# Altium schematic sheet VoltageRegulator5V.schdoc
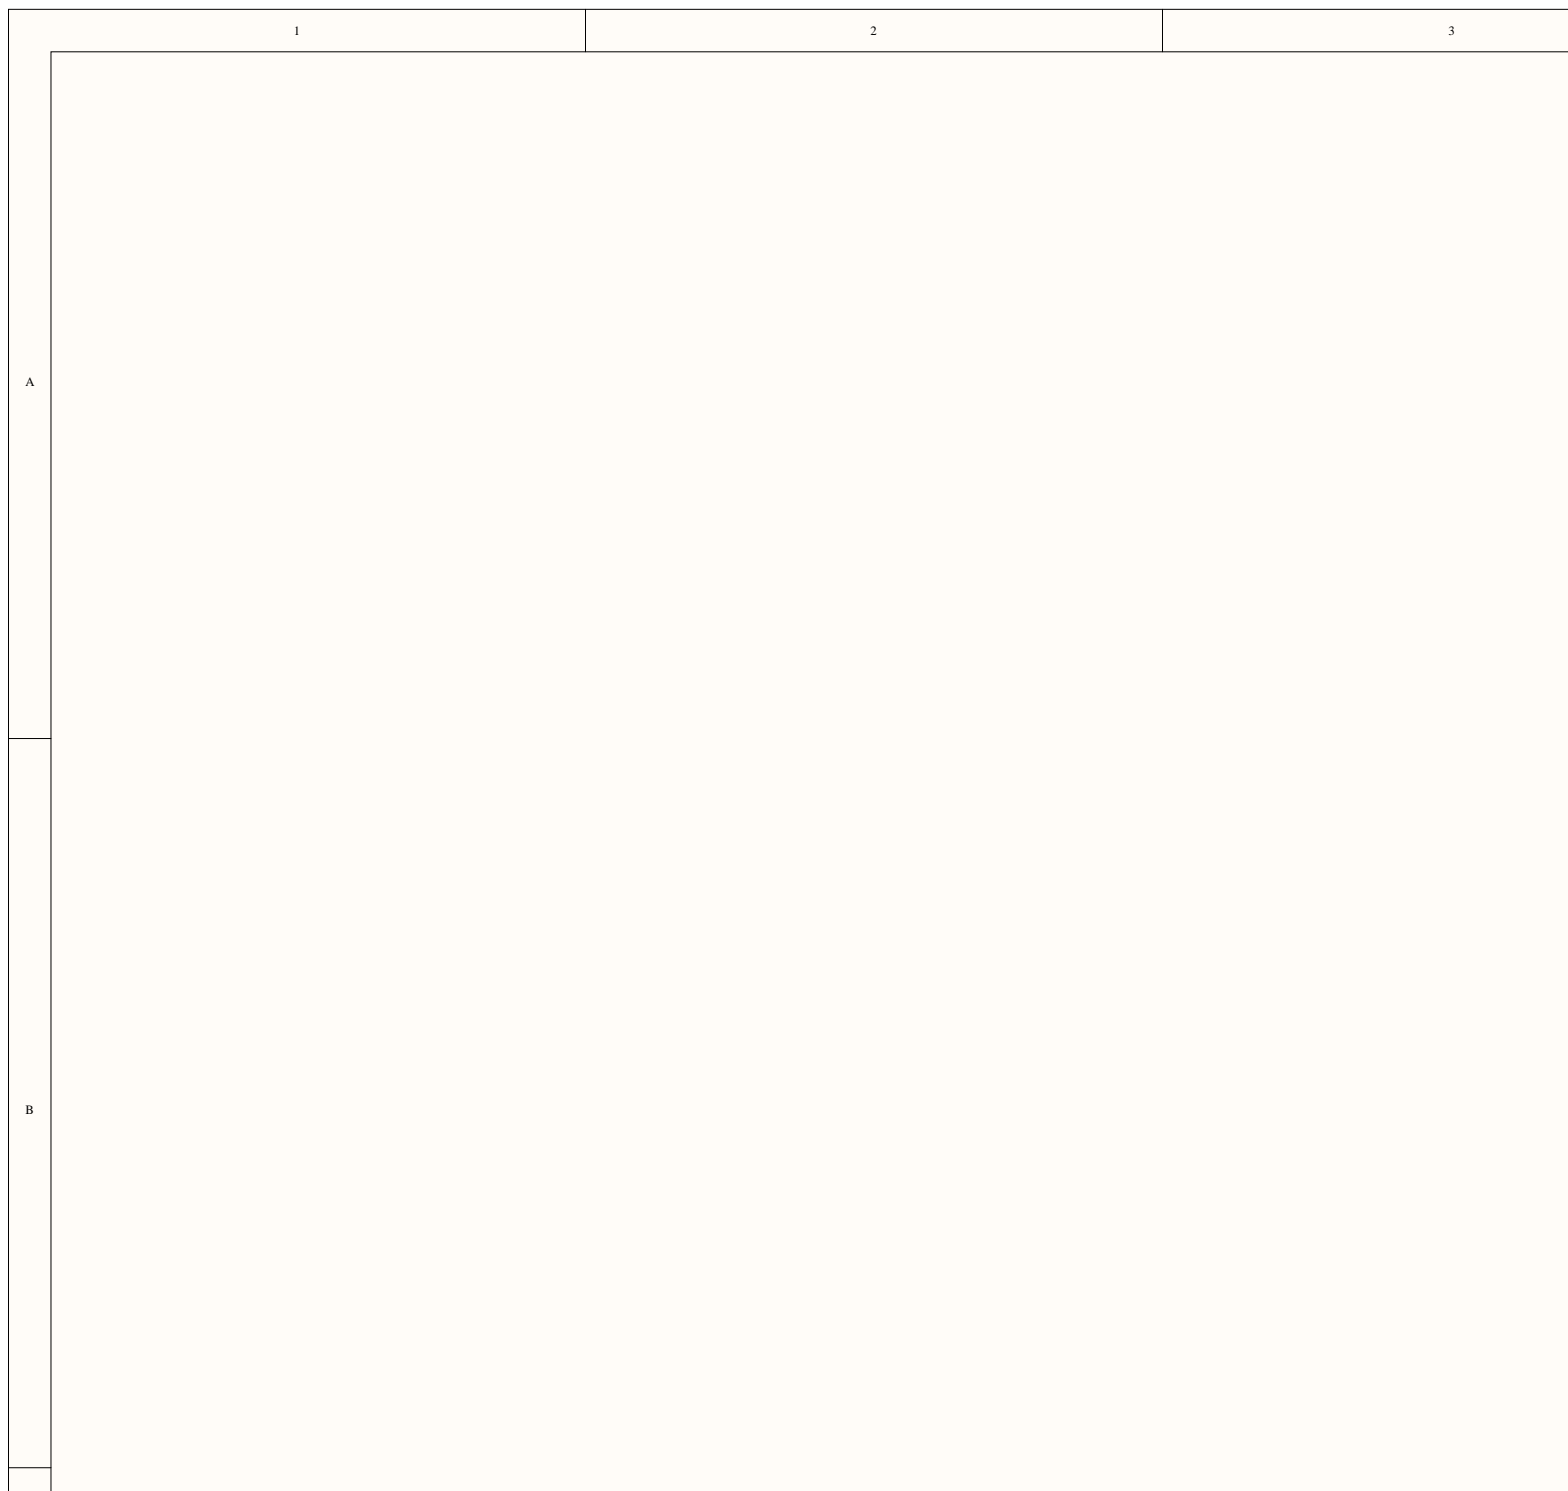
[diagram p0: VoltageRegulator5V.schdoc, top left]
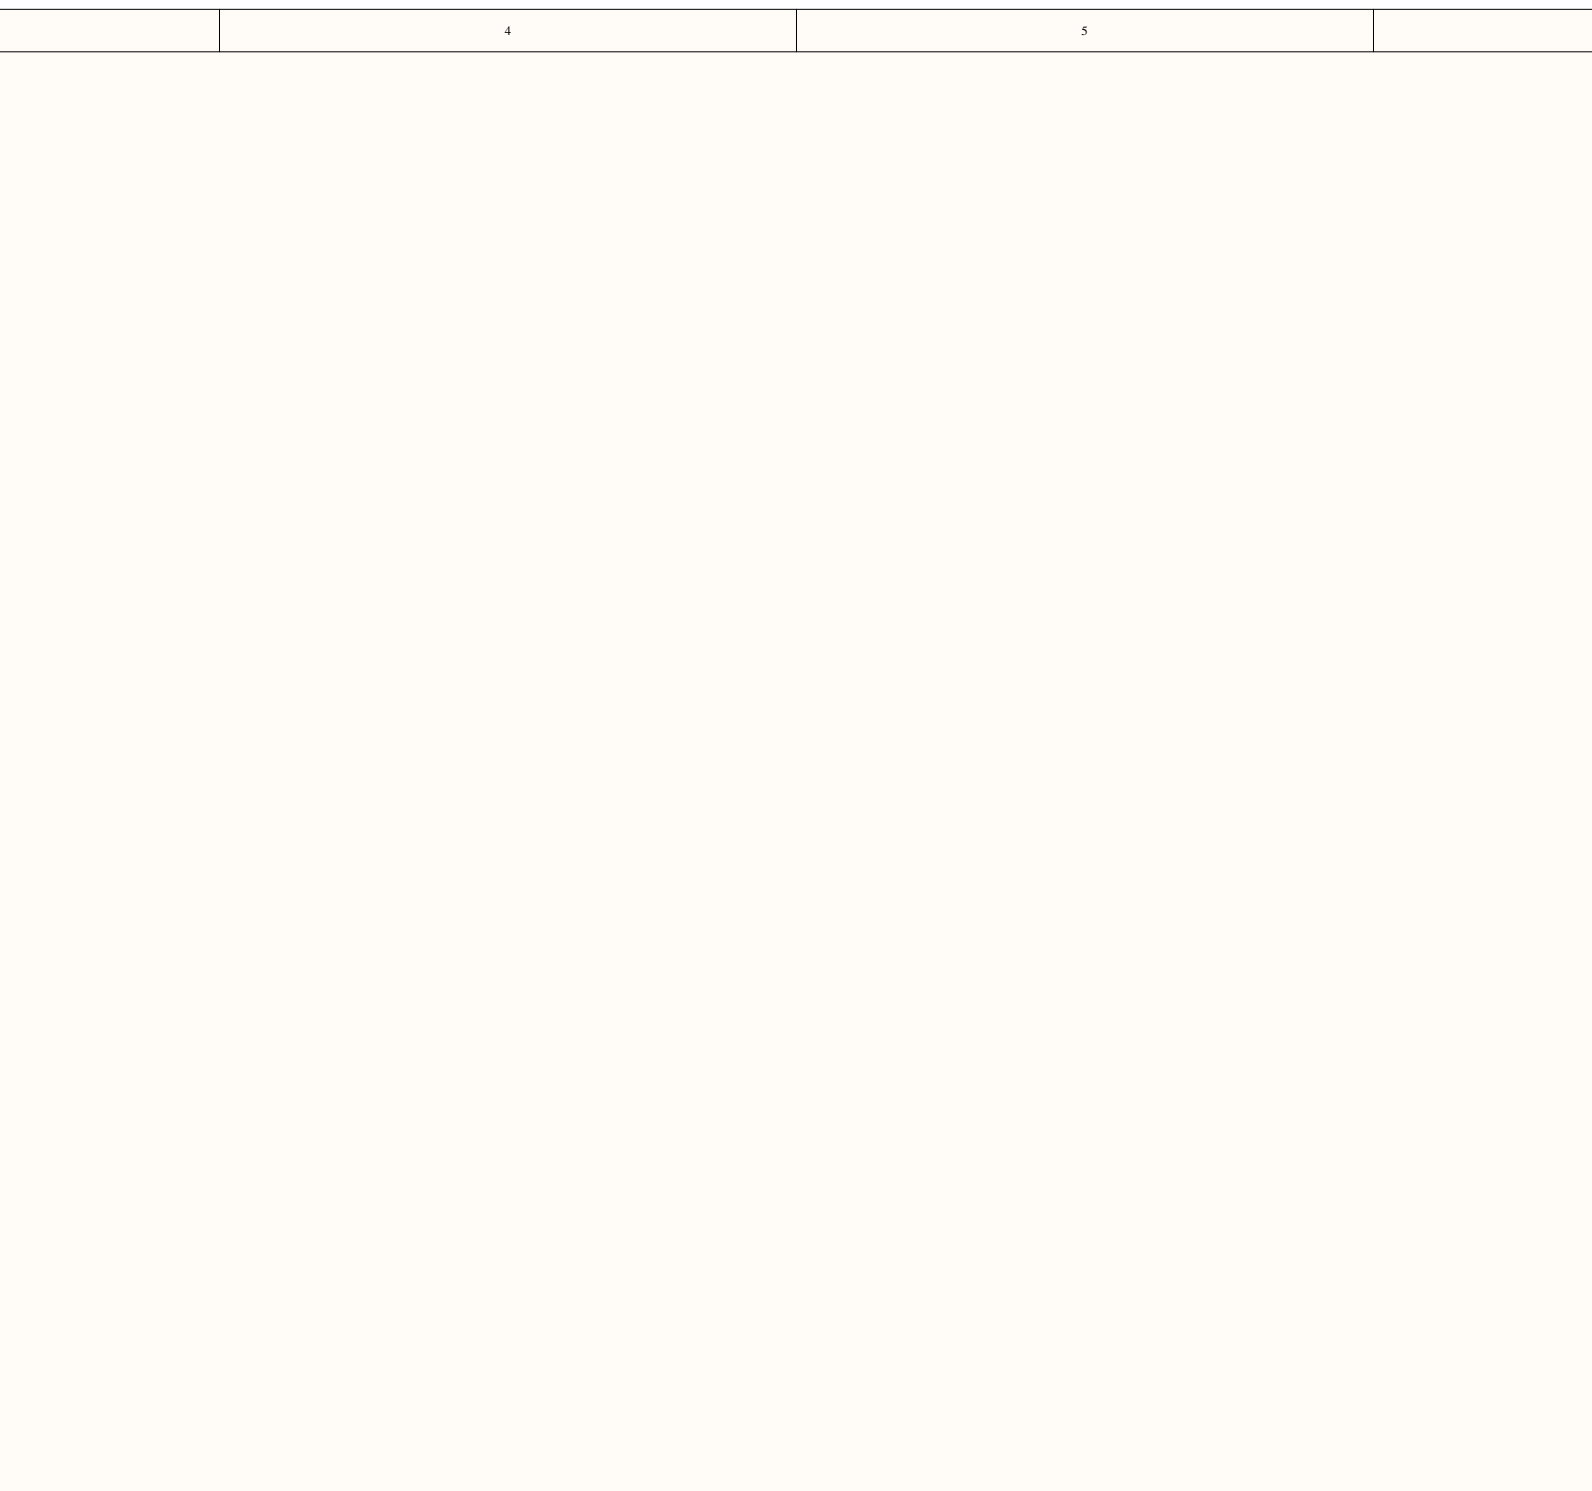
[diagram p1: VoltageRegulator5V.schdoc, top centre]
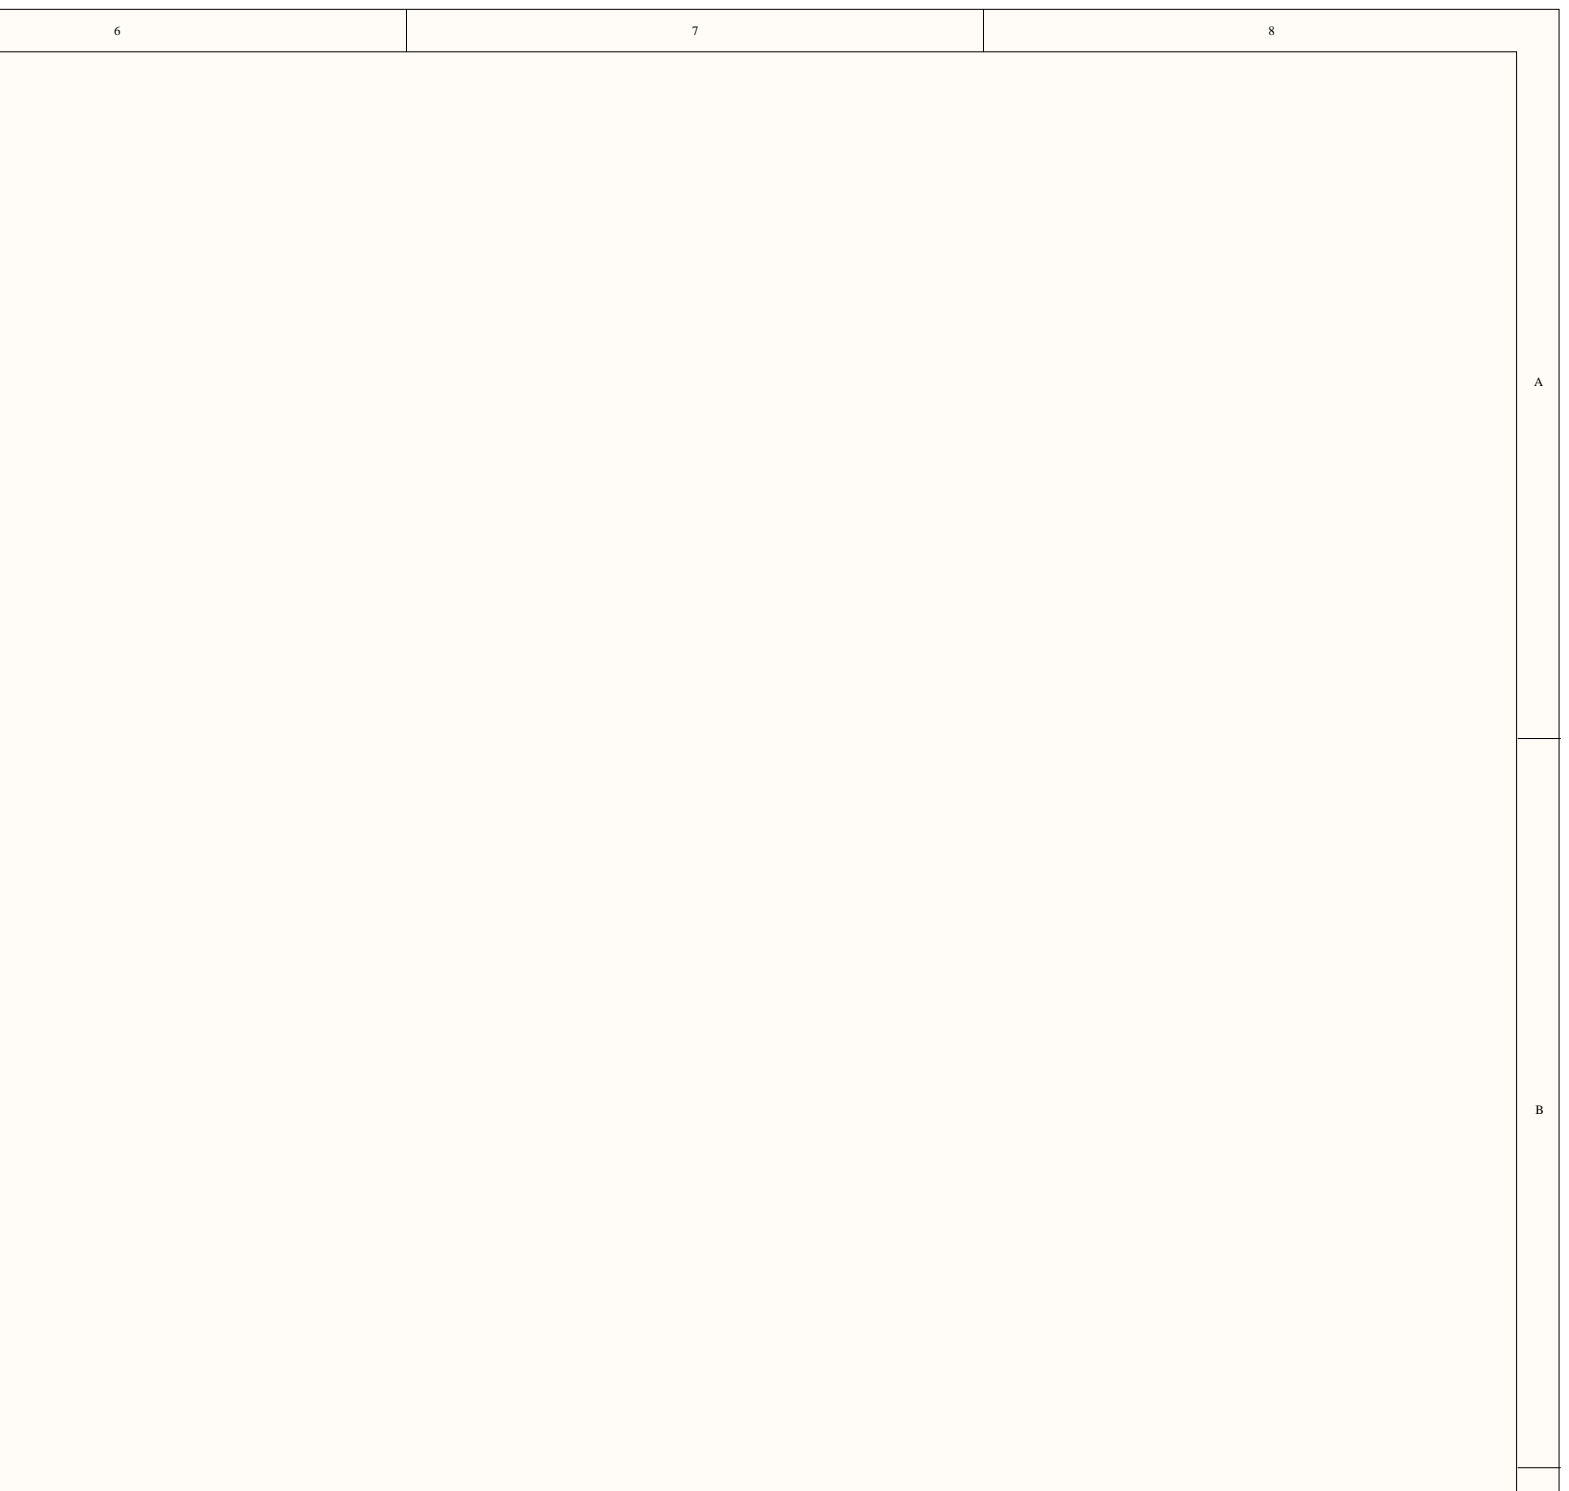
[diagram p2: VoltageRegulator5V.schdoc, top right]
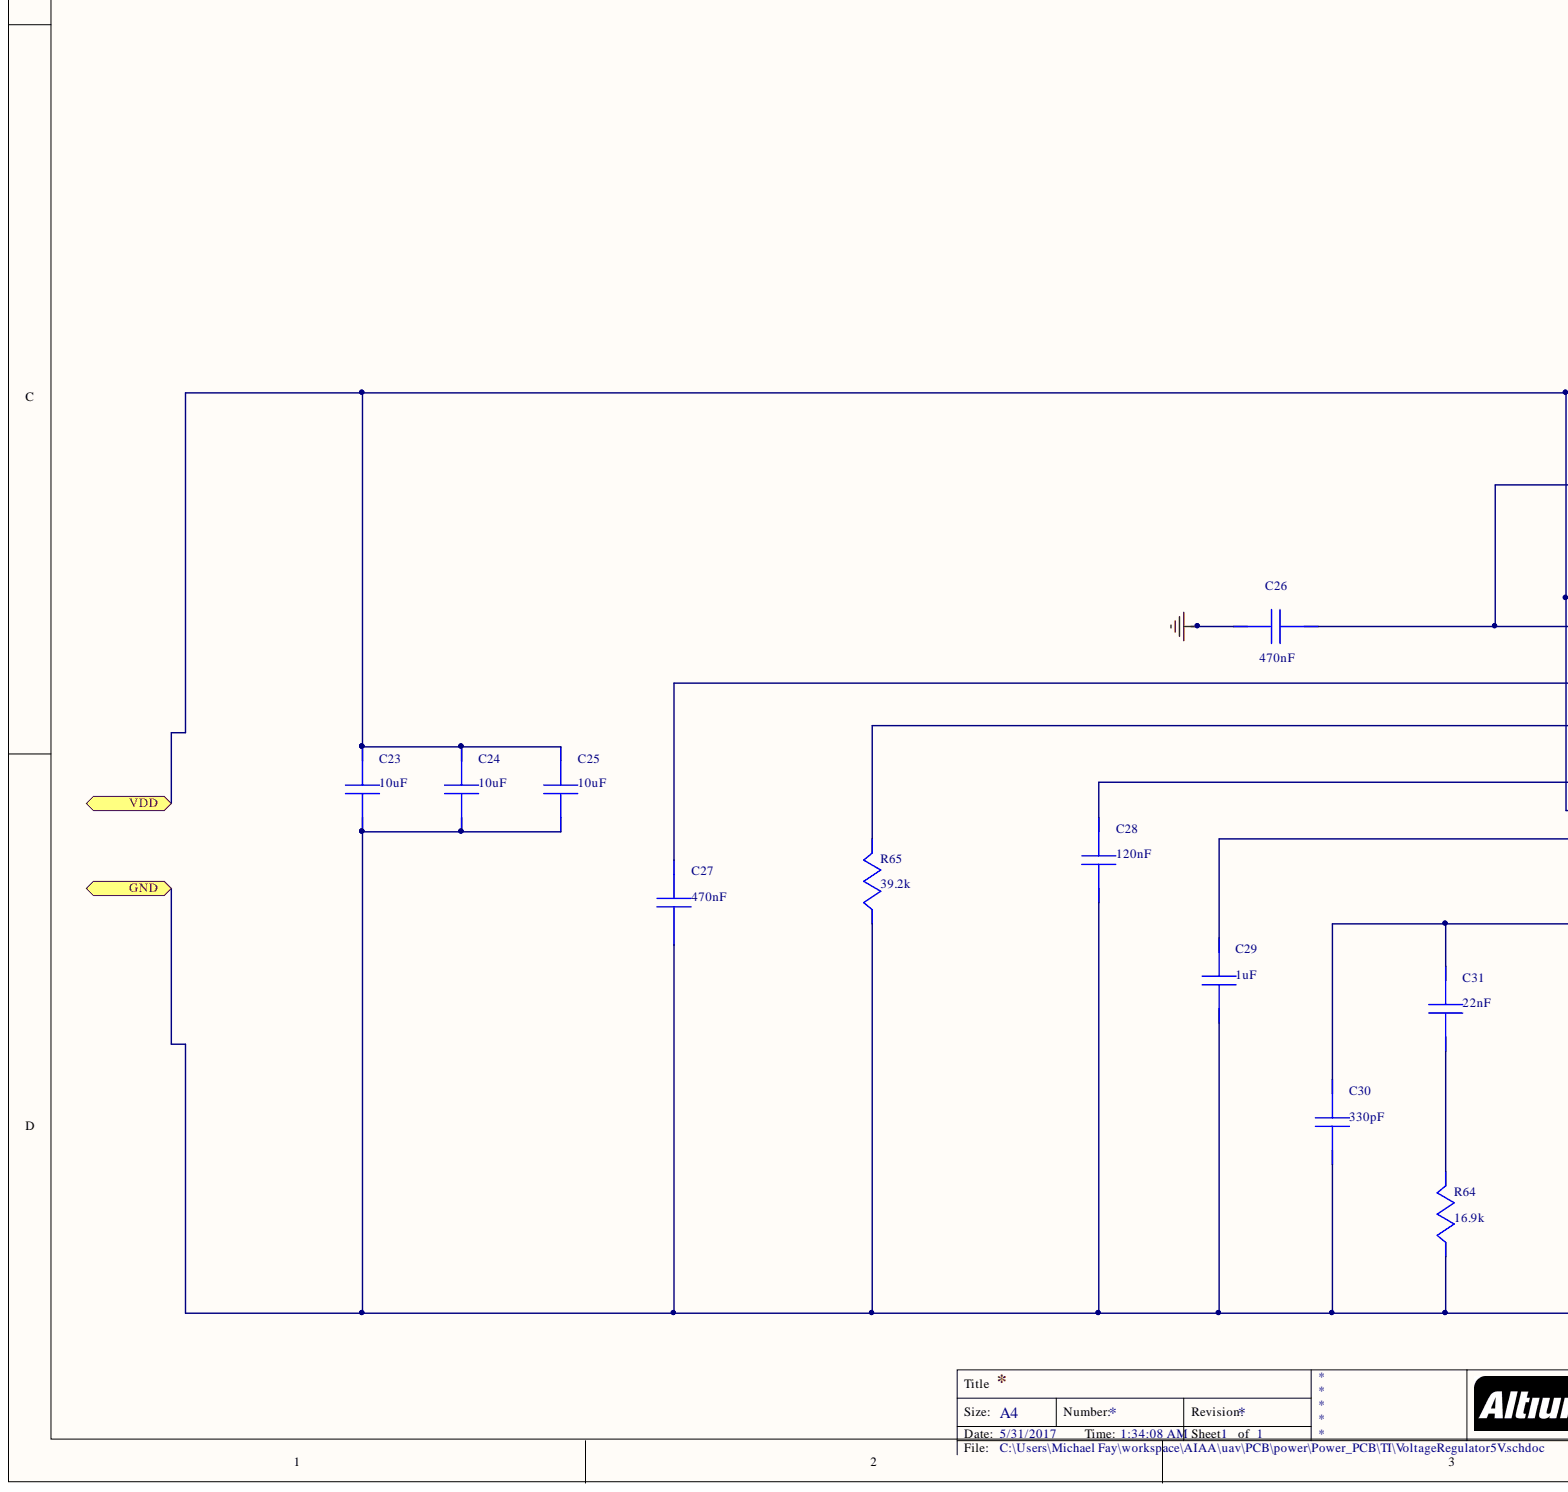
[diagram p3: VoltageRegulator5V.schdoc, bottom left]
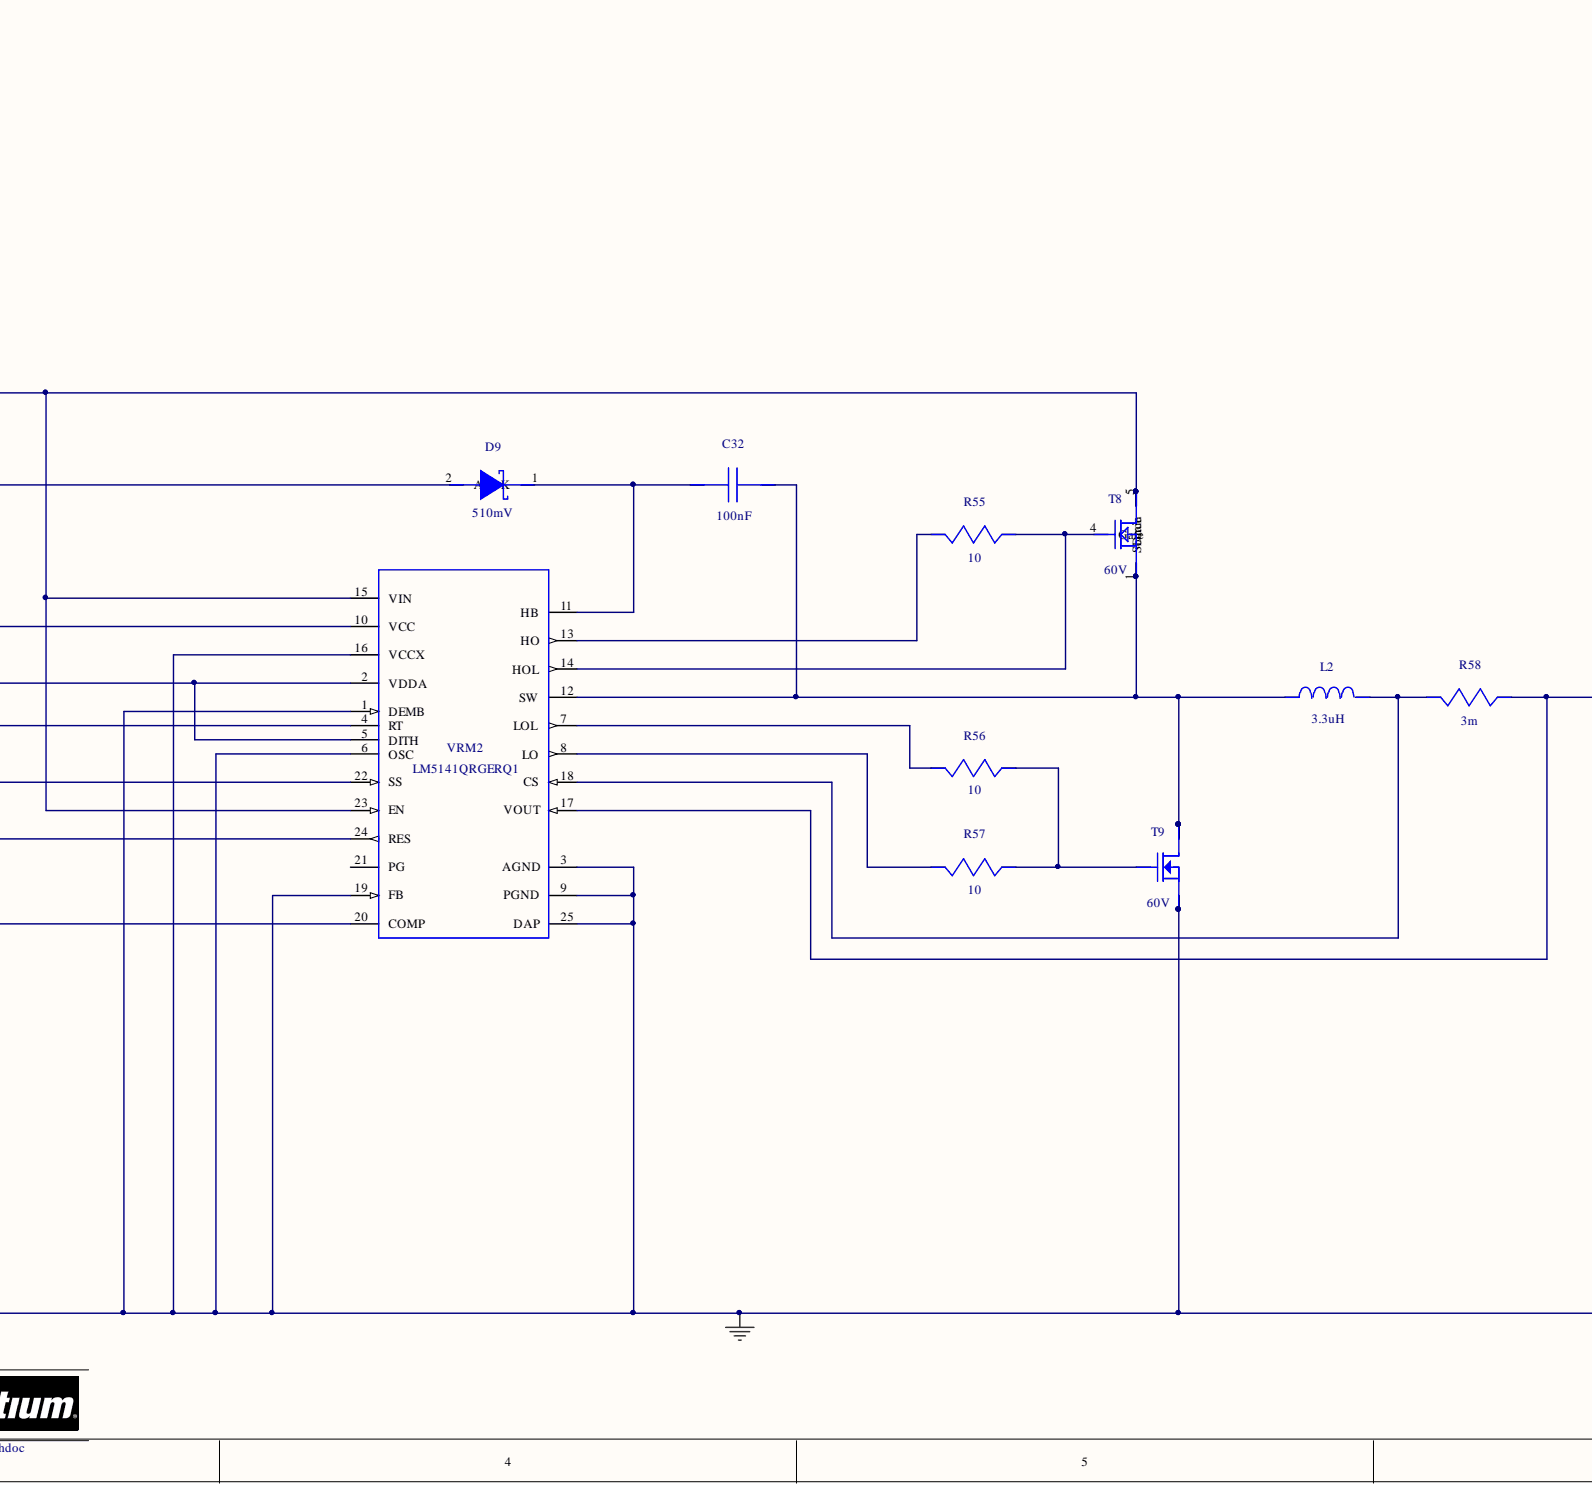
[diagram p4: VoltageRegulator5V.schdoc, bottom centre]
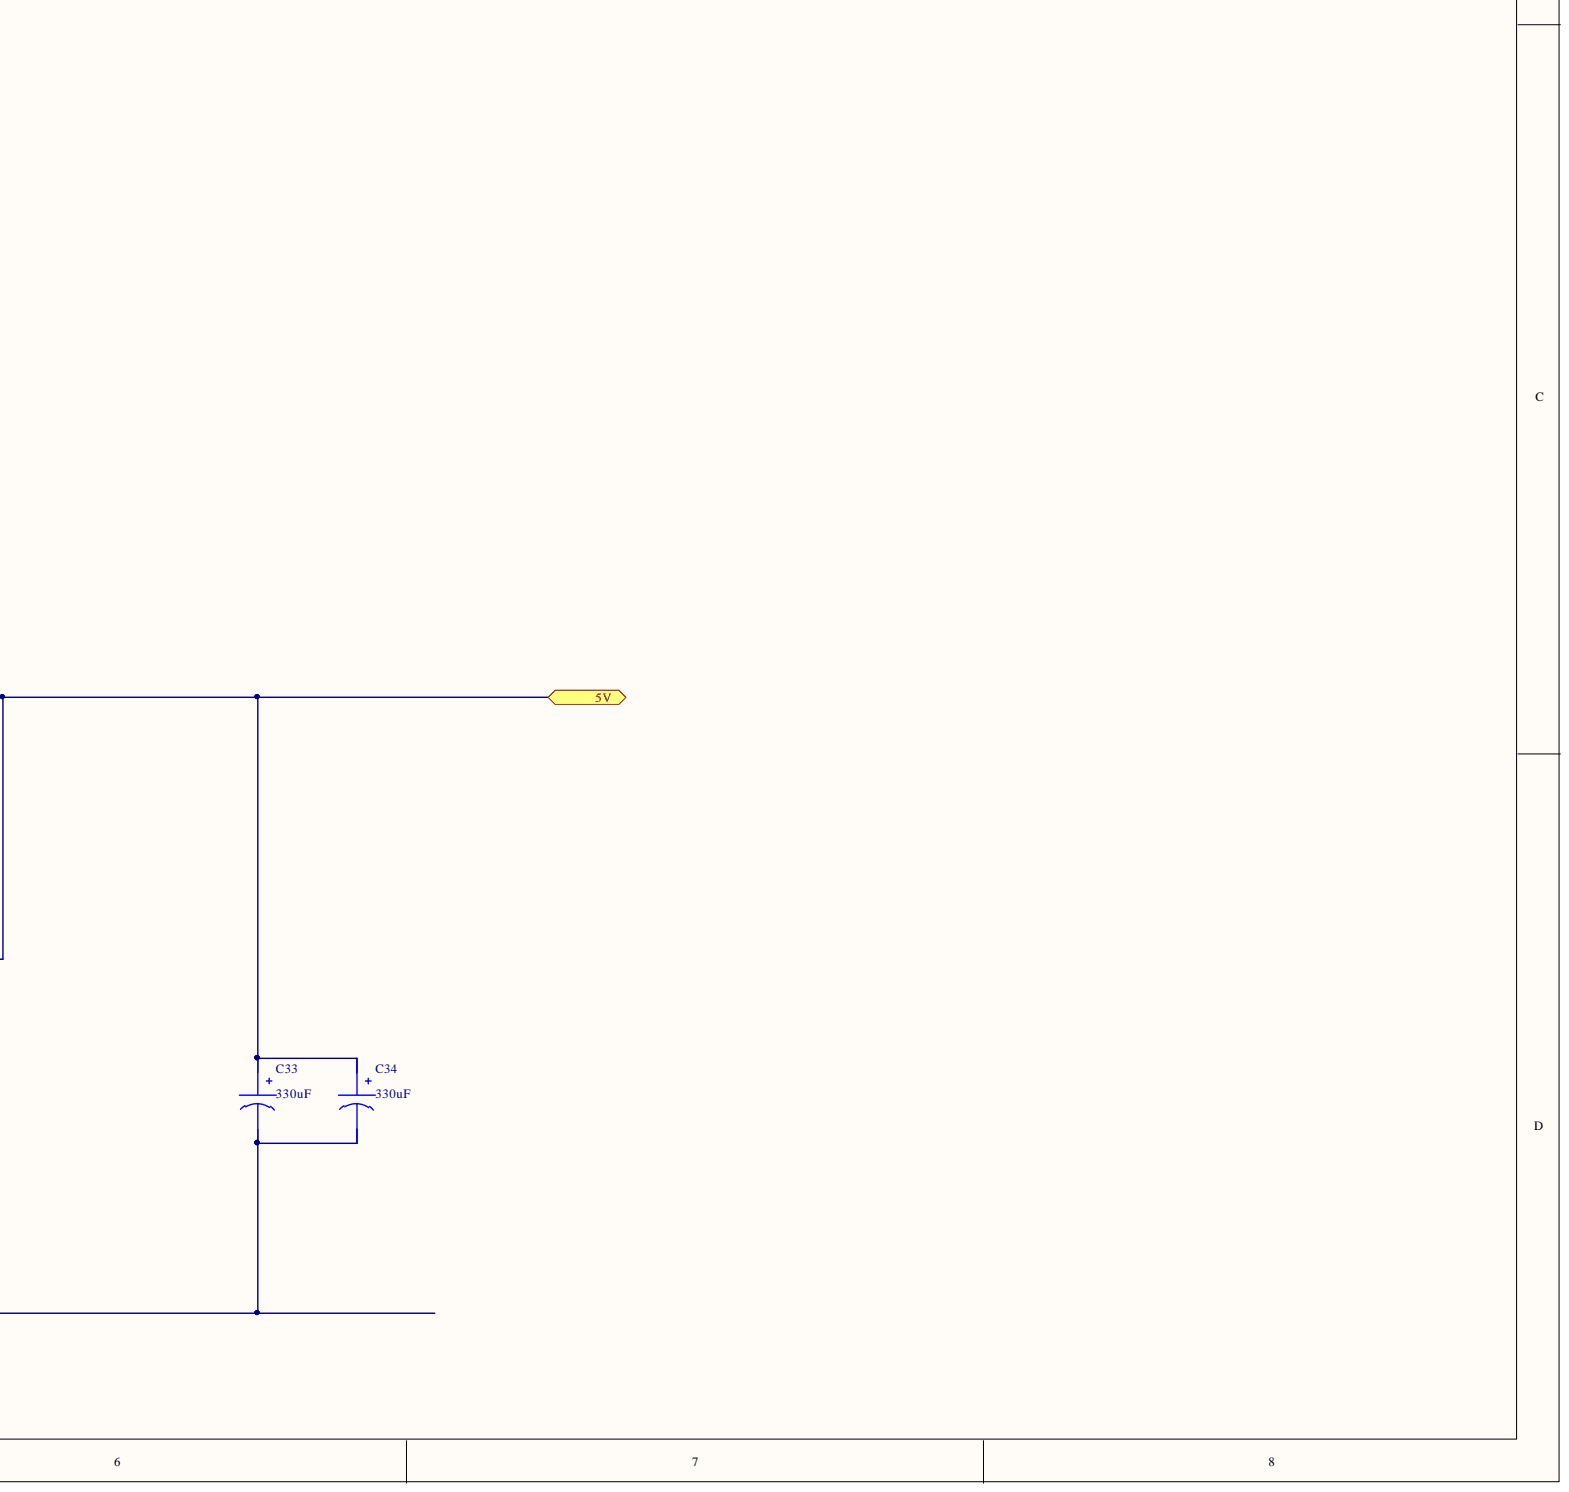
[diagram p5: VoltageRegulator5V.schdoc, bottom right]

## Parts
C23  value 10uF  (hidden comment: C3216X5R1H106K160AB)
C24  value 10uF  (hidden comment: C3216X5R1H106K160AB)
C25  value 10uF  (hidden comment: C3216X5R1H106K160AB)
C26  value 470nF  (hidden comment: GRM155R60J474KE19D)
C27  value 470nF  (hidden comment: GRM155R60J474KE19D)
C28  value 120nF  (hidden comment: GRM155C80J124KE01D)
C29  value 1uF  (hidden comment: EMK212B7105KG-T)
C30  value 330pF  (hidden comment: CL21C331JBANFNC)
C31  value 22nF  (hidden comment: CC0805KRX7R9BB223)
C32  value 100nF  (hidden comment: GRM155R60J104KA01D)
C33  value 330uF  (hidden comment: 16SVP330M)
C34  value 330uF  (hidden comment: 16SVP330M)
D9  value 510mV  (hidden comment: CDBK0540)
L2  value 3.3uH  (hidden comment: SRP1250-3R3M)
R55  value 10  (hidden comment: CRCW040210R0FKED)
R56  value 10  (hidden comment: CRCW040210R0FKED)
R57  value 10  (hidden comment: CRCW040210R0FKED)
R58  value 3m  (hidden comment: CSNL1206FT3L00)
R64  value 16.9k  (hidden comment: CRCW040216K9FKED)
R65  value 39.2k  (hidden comment: CRCW040239K2FKED)
T8  value 60V  (hidden comment: CSD18543Q3A)
T9  value 60V  (hidden comment: CSD18531Q5A)
VRM2  LM5141QRGERQ1

## Ports
5V, GND, VDD

## Nets
0: C23.2 C24.2 C25.2 C26.2 C27.2 C28.2 C29.2 C30.2 C33.2 C34.2 R64.2 R65.2 T9.1 T9.2 T9.3 VRM2.1(DEMB) VRM2.3(AGND) VRM2.6(OSC) VRM2.9(PGND) VRM2.16(VCCX) VRM2.19(FB) VRM2.25(DAP) ; port GND
5V: C33.1 C34.1 R58.2 VRM2.17(VOUT) ; port 5V
VDD: C23.1 C24.1 C25.1 T8.5(Drain) T8.6 T8.7 T8.8 T8.9 VRM2.15(VIN) VRM2.23(EN) ; port VDD
NetC26_1: C26.1 D9.2 VRM2.10(VCC)
NetC27_1: C27.1 VRM2.2(VDDA) VRM2.5(DITH)
NetC28_1: C28.1 VRM2.22(SS)
NetC29_1: C29.1 VRM2.24(RES)
NetC30_1: C30.1 C31.1 VRM2.20(COMP)
NetC31_2: C31.2 R64.1
NetC32_1: C32.1 D9.1 VRM2.11(HB)
NetC32_2: C32.2 L2.1 T8.1(Source) T8.2 T8.3 T9.5 T9.6 T9.7 T9.8 T9.9 VRM2.12(SW)
NetL2_2: L2.2 R58.1 VRM2.18(CS)
NetR55_1: R55.1 VRM2.13(HO)
NetR55_2: R55.2 T8.4(Gate) VRM2.14(HOL)
NetR56_1: R56.1 VRM2.7(LOL)
NetR56_2: R56.2 R57.2 T9.4
NetR57_1: R57.1 VRM2.8(LO)
NetR65_1: R65.1 VRM2.4(RT)

Not connected: VRM2 21(PG)

## Library data of the parts
C23-C25 = C3216X5R1H106K160AB: C3216X5R1H106K16; Manufacturer Name: TDK; Component Kind: Standard; Library Name: TI WEBENCH; Manufacturer Part Number: C3216X5R1H106K160AB; PackageReference: C3216X5R1H106K160AB
C26 C27 = GRM155R60J474KE19D: GRM155R60J474KE1; Manufacturer Name: MuRata; Component Kind: Standard; Library Name: TI WEBENCH; Manufacturer Part Number: GRM155R60J474KE19D; PackageReference: GRM155R60J474KE19D
C28 = GRM155C80J124KE01D: GRM155C80J124KE0; Manufacturer Name: MuRata; Component Kind: Standard; Library Name: TI WEBENCH; Manufacturer Part Number: GRM155C80J124KE01D; PackageReference: GRM155C80J124KE01D
C29 = EMK212B7105KG-T: Manufacturer Name: Taiyo Yuden; Component Kind: Standard; Description: EMK212B7105KG-T; Library Name: TI WEBENCH; Manufacturer Part Number: EMK212B7105KG-T; PackageReference: EMK212B7105KG-T
C30 = CL21C331JBANFNC: Manufacturer Name: Samsung Electro-Mechanics; Component Kind: Standard; Description: CL21C331JBANFNC; Library Name: TI WEBENCH; Manufacturer Part Number: CL21C331JBANFNC; PackageReference: CL21C331JBANFNC
C31 = CC0805KRX7R9BB223: CC0805KRX7R9BB22; Manufacturer Name: Yageo America; Component Kind: Standard; Library Name: TI WEBENCH; Manufacturer Part Number: CC0805KRX7R9BB223; PackageReference: CC0805KRX7R9BB223
C32 = GRM155R60J104KA01D: GRM155R60J104KA0; Manufacturer Name: MuRata; Component Kind: Standard; Library Name: TI WEBENCH; Manufacturer Part Number: GRM155R60J104KA01D; PackageReference: GRM155R60J104KA01D
C33 C34 = 16SVP330M: Manufacturer Name: Panasonic; Component Kind: Standard; Description: 16SVP330M; Library Name: TI WEBENCH; Manufacturer Part Number: 16SVP330M; PackageReference: 16SVP330M
D9 = CDBK0540: Manufacturer Name: Comchip Technology; Component Kind: Standard; Description: CDBK0540; Library Name: TI WEBENCH; Manufacturer Part Number: CDBK0540; PackageReference: CDBK0540
L2 = SRP1250-3R3M: Manufacturer Name: Bourns; Component Kind: Standard; Description: SRP1250-3R3M; Library Name: TI WEBENCH; Manufacturer Part Number: SRP1250-3R3M; PackageReference: SRP1250-3R3M
R55-R57 = CRCW040210R0FKED: Manufacturer Name: Vishay-Dale; Component Kind: Standard; Description: CRCW040210R0FKED; Library Name: TI WEBENCH; Manufacturer Part Number: CRCW040210R0FKED; PackageReference: CRCW040210R0FKED
R58 = CSNL1206FT3L00: Manufacturer Name: Stackpole Electronics Inc; Component Kind: Standard; Description: CSNL1206FT3L00; Library Name: TI WEBENCH; Manufacturer Part Number: CSNL1206FT3L00; PackageReference: CSNL1206FT3L00
R64 = CRCW040216K9FKED: Manufacturer Name: Vishay-Dale; Component Kind: Standard; Description: CRCW040216K9FKED; Library Name: TI WEBENCH; Manufacturer Part Number: CRCW040216K9FKED; PackageReference: CRCW040216K9FKED
R65 = CRCW040239K2FKED: Manufacturer Name: Vishay-Dale; Component Kind: Standard; Description: CRCW040239K2FKED; Library Name: TI WEBENCH; Manufacturer Part Number: CRCW040239K2FKED; PackageReference: CRCW040239K2FKED
T8 = CSD18543Q3A: Manufacturer Name: Texas Instruments; Component Kind: Standard; Description: CSD18543Q3A; Library Name: TI WEBENCH; Manufacturer Part Number: CSD18543Q3A; PackageReference: CSD18543Q3A
T9 = CSD18531Q5A: Manufacturer Name: Texas Instruments; Component Kind: Standard; Description: CSD18531Q5A; Library Name: TI WEBENCH; Manufacturer Part Number: CSD18531Q5A; PackageReference: CSD18531Q5A
VRM2 = LM5141QRGERQ1: Manufacturer Name: Texas Instruments; Component Kind: Standard; Description: LM5141QRGERQ1; Library Name: TI WEBENCH; Manufacturer Part Number: LM5141QRGERQ1; PackageReference: LM5141QRGERQ1; Value: N/A
